# Altium schematic sheet bmsMaster_led.SchDoc
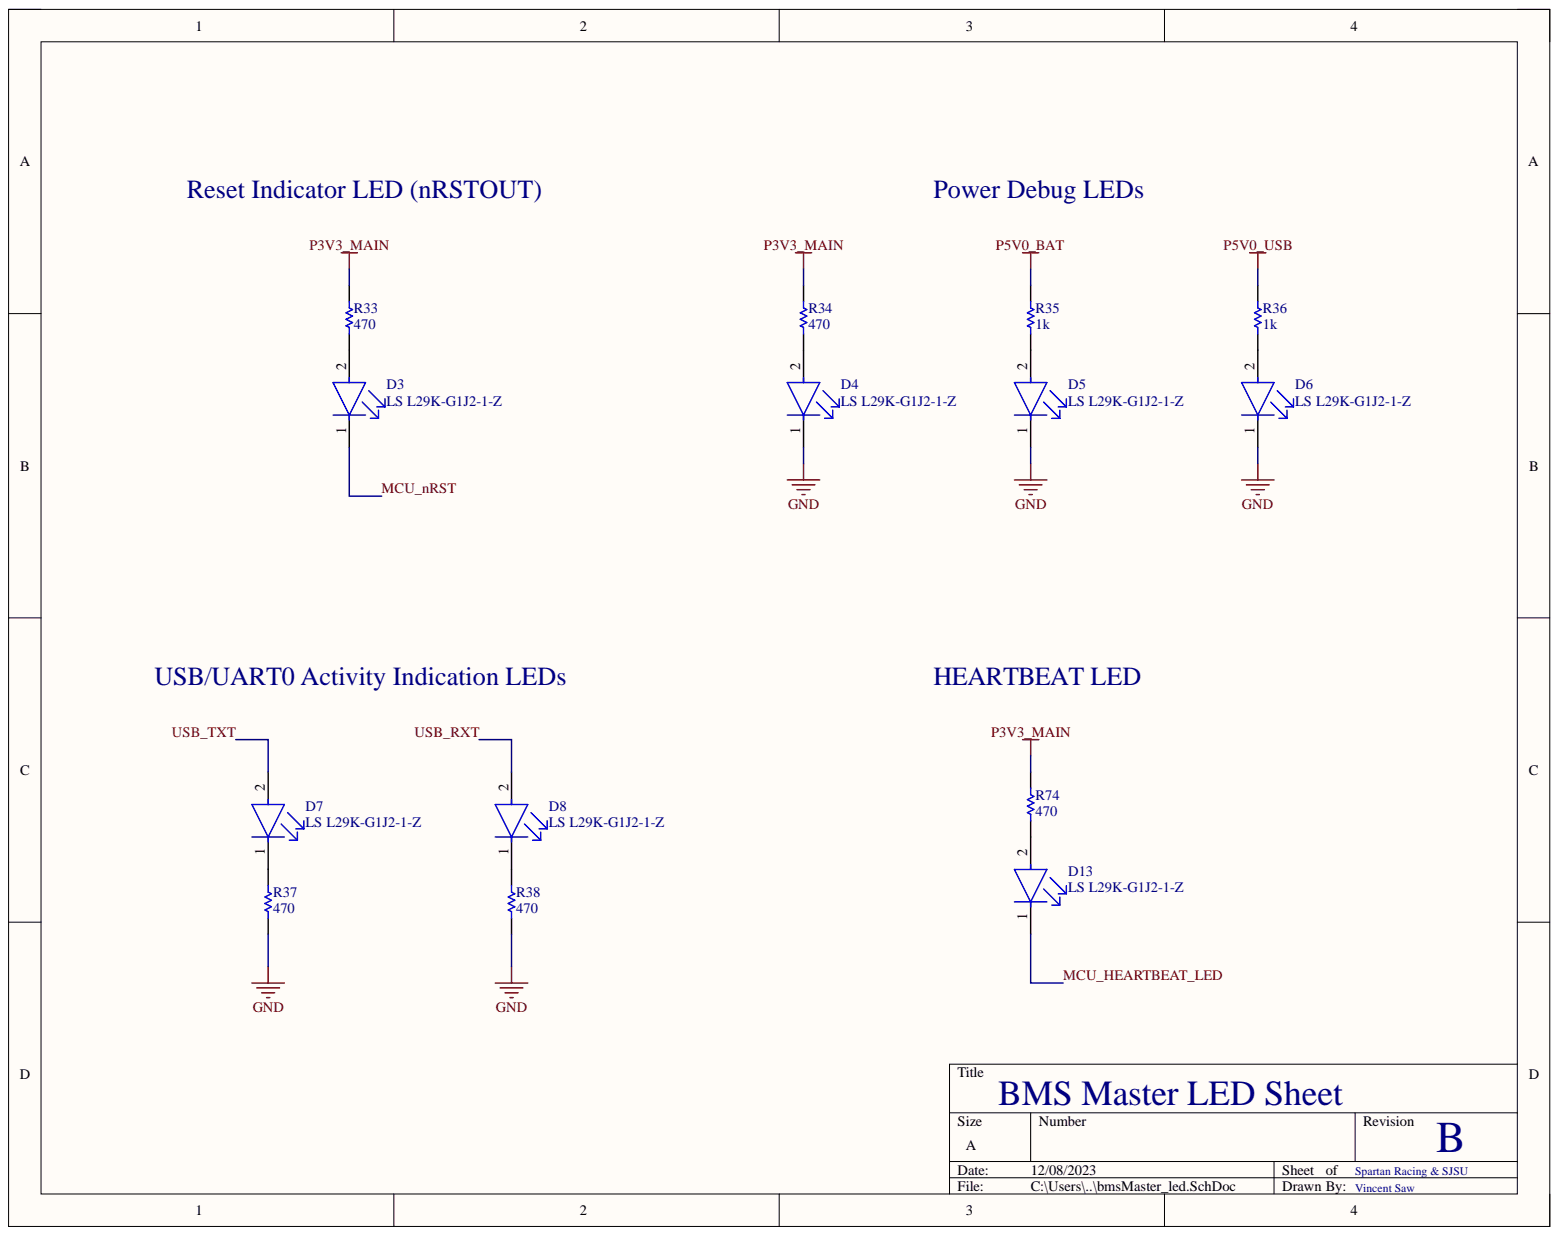
[diagram p0: bmsMaster_led.SchDoc]

## Parts
D3  LS L29K-G1J2-1-Z  [FP-LS_L29K-MFG]
D4  LS L29K-G1J2-1-Z  [FP-LS_L29K-MFG]
D5  LS L29K-G1J2-1-Z  [FP-LS_L29K-MFG]
D6  LS L29K-G1J2-1-Z  [FP-LS_L29K-MFG]
D7  LS L29K-G1J2-1-Z  [FP-LS_L29K-MFG]
D8  LS L29K-G1J2-1-Z  [FP-LS_L29K-MFG]
D13  LS L29K-G1J2-1-Z  [FP-LS_L29K-MFG]
R33  value 470  [RESC1608X50N]  (hidden comment: Resistor)
R34  value 470  [RESC1608X50N]  (hidden comment: Resistor)
R35  value 1k  [RESC1608X50N]  (hidden comment: Resistor)
R36  value 1k  [RESC1608X50N]  (hidden comment: Resistor)
R37  value 470  [RESC1608X50N]  (hidden comment: Resistor)
R38  value 470  [RESC1608X50N]  (hidden comment: Resistor)
R74  value 470  [RESC1608X50N]  (hidden comment: Resistor)

## Nets
GND: D4.1 D5.1 D6.1 R37.1 R38.1
P3V3_MAIN: R33.2 R34.2 R74.2
P5V0_BAT: R35.2
P5V0_USB: R36.2
MCU_HEARTBEAT_LED: D13.1
MCU_nRST: D3.1
USB_RXT: D8.2
USB_TXT: D7.2
NetD3_2: D3.2 R33.1
NetD4_2: D4.2 R34.1
NetD5_2: D5.2 R35.1
NetD6_2: D6.2 R36.1
NetD7_1: D7.1 R37.2
NetD8_1: D8.1 R38.2
NetD13_2: D13.2 R74.1

## Library data of the parts
D3-D8 D13 = CMP-62016-000014-1: LED RED DIFFUSED 0603 SMD; Manufacturer: Osram Opto; Forward Current: 2mA; Forward Voltage: 1.8V; Led Orientation: Top View; Luminous Flux: 0.0081/0.0254lm; Luminous Intensity: 1.8-2.24/5.6-7.1mcd; Max Junction Temp: +110°C; Peak Emmision Wavelength: 643nm; Reverse Voltage: 12V; Viewing Angle: 160°; Wavelength: 630nm; Automotive: No; Category: Diode; Colour: Red; Component Kind: Standard; ComponentLink2Description: Datasheet; ComponentLink2URL: https://dammedia.osram.info/media/resource/hires/osram-dam-5662679/LS%20L29K_EN.pdf; Device Class L1: Optoelectronics; Device Class L2: LEDs; Device Class L3: unset; Digikey Description: LED RED DIFFUSED 0603 SMD; Height: 0.67mm; Lead Free: Yes; Library Name: Altium Content Vault; Manufacturer Part Number: LS L29K-G1J2-1-Z; Mouser Description: LED Uni-Color Red 643nm Automotive 2-Pin SMD T/R; Octopart: https://octopart.com/ls+l29k-g1j2-1-z-osram+opto-31093939; Package: LS_L29K; RoHS: TRUE; Temperature: -40°C to +100°C; Temperature Range High: +100°C; Temperature Range Low: -40°C
R33-R38 R74 = Resistor: SimulationGenericComponent: True; TC1: 0; Component Kind: Standard; Description: Resistor; Library Name: Simulation Generic Components.IntLib; Simulation: R_Resistor_Default; TC2: 0
